# Altium schematic sheet MainSheet.SchDoc(MainSheet)
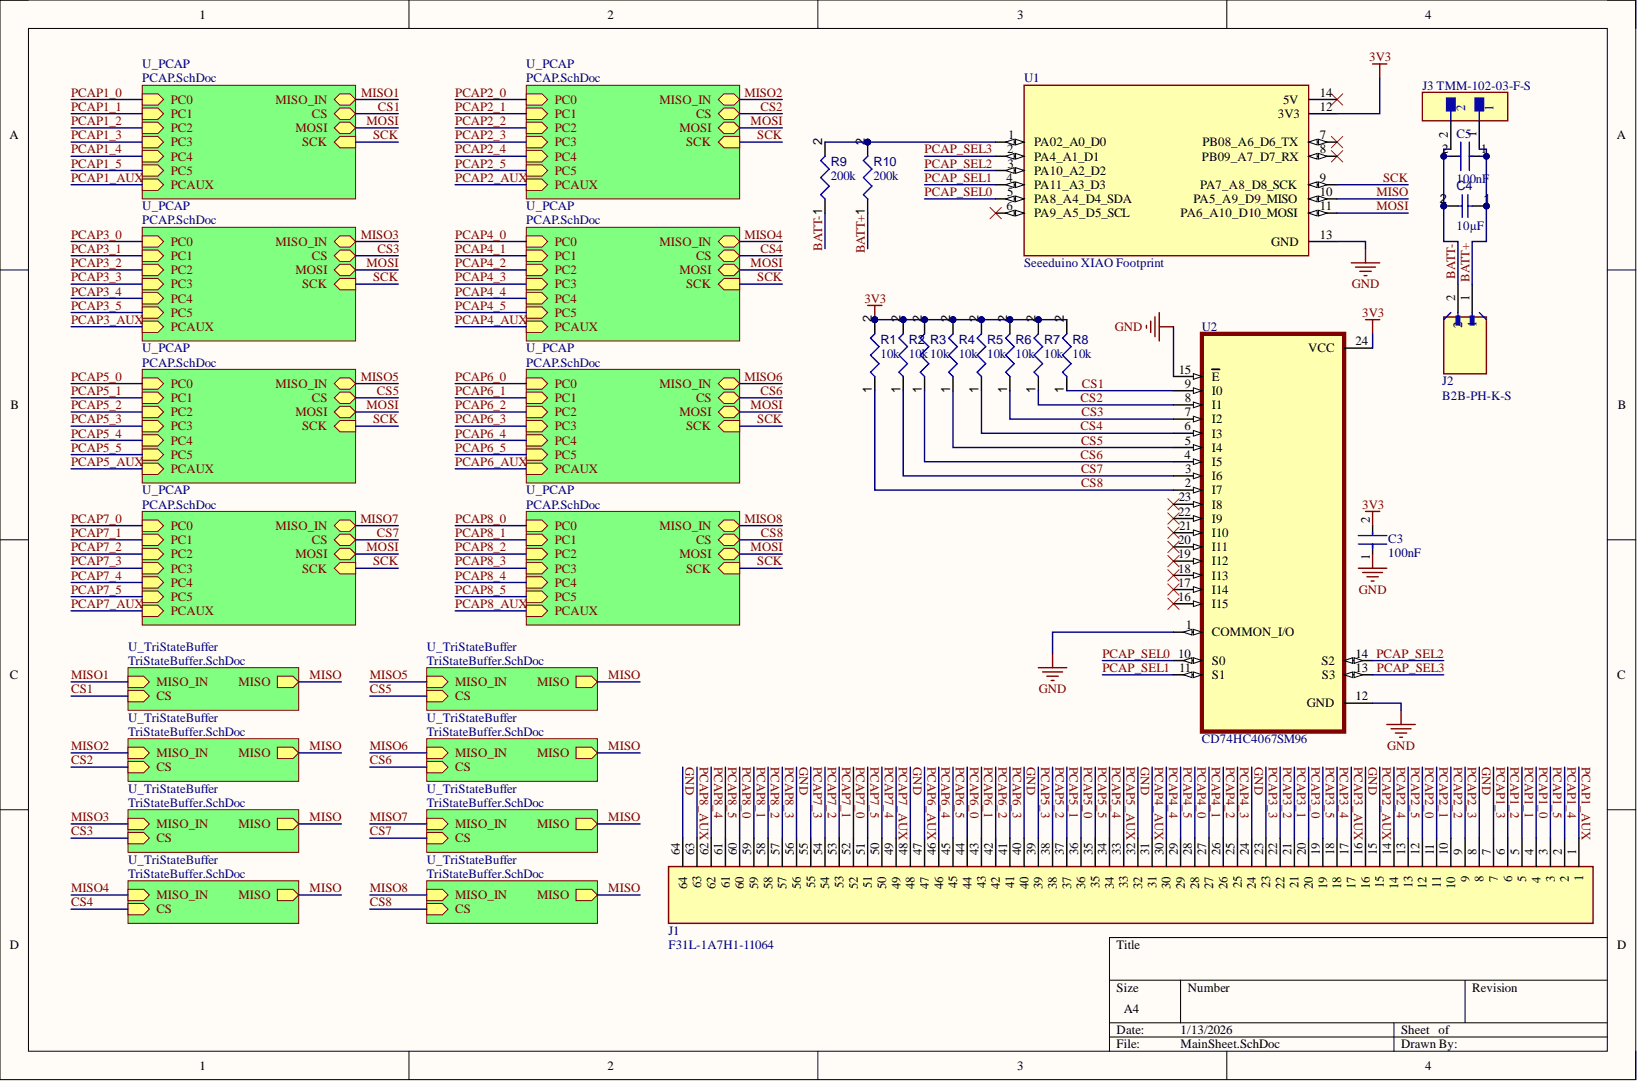
[diagram p0: MainSheet.SchDoc]

## Parts
C3  100nF
C4  value 10µF  (hidden comment: Capacitor 10µF +/-20% 10V 0603)
C5  100nF
J1  F31L-1A7H1-11064
J2  B2B-PH-K-S
J3  TMM-102-03-F-S
R1  value 10k  (hidden comment: 10KR2F)
R2  value 10k  (hidden comment: 10KR2F)
R3  value 10k  (hidden comment: 10KR2F)
R4  value 10k  (hidden comment: 10KR2F)
R5  value 10k  (hidden comment: 10KR2F)
R6  value 10k  (hidden comment: 10KR2F)
R7  value 10k  (hidden comment: 10KR2F)
R8  value 10k  (hidden comment: 10KR2F)
R9  value 200k  (hidden comment: Resistor 200K +/-1 % 0402 63 mW)
R10  value 200k  (hidden comment: Resistor 200K +/-1 % 0402 63 mW)
U1  Seeeduino XIAO Footprint
U2  CD74HC4067SM96

## Nets
3V3: C3.2 R1.2 R2.2 R3.2 R4.2 R5.2 R6.2 R7.2 R8.2 U1.12(3V3) U2.24(VCC)
BATT+: C4.1 C5.1 J2.1 J3.1 R10.1
BATT-: C4.2 C5.2 J2.2 J3.2 R9.1
CS1: R8.1 U2.9(I0)
CS2: R7.1 U2.8(I1)
CS3: R6.1 U2.7(I2)
CS4: R5.1 U2.6(I3)
CS5: R4.1 U2.5(I4)
CS6: R3.1 U2.4(I5)
CS7: R2.1 U2.3(I6)
CS8: R1.1 U2.2(I7)
GND: C3.1 J1.8 J1.16 J1.24 J1.32 J1.40 J1.48 J1.56 J1.64 U1.13(GND) U2.1(COMMON_I/O) U2.12(GND) U2.15(E)
MISO: U1.10(PA5_A9_D9_MISO)
MOSI: U1.11(PA6_A10_D10_MOSI)
PCAP1_0: J1.4
PCAP1_1: J1.5
PCAP1_2: J1.6
PCAP1_3: J1.7
PCAP1_4: J1.2
PCAP1_5: J1.3
PCAP1_AUX: J1.1
PCAP2_0: J1.12
PCAP2_1: J1.11
PCAP2_2: J1.10
PCAP2_3: J1.9
PCAP2_4: J1.14
PCAP2_5: J1.13
PCAP2_AUX: J1.15
PCAP3_0: J1.20
PCAP3_1: J1.21
PCAP3_2: J1.22
PCAP3_3: J1.23
PCAP3_4: J1.18
PCAP3_5: J1.19
PCAP3_AUX: J1.17
PCAP4_0: J1.28
PCAP4_1: J1.27
PCAP4_2: J1.26
PCAP4_3: J1.25
PCAP4_4: J1.30
PCAP4_5: J1.29
PCAP4_AUX: J1.31
PCAP5_0: J1.36
PCAP5_1: J1.37
PCAP5_2: J1.38
PCAP5_3: J1.39
PCAP5_4: J1.34
PCAP5_5: J1.35
PCAP5_AUX: J1.33
PCAP6_0: J1.44
PCAP6_1: J1.43
PCAP6_2: J1.42
PCAP6_3: J1.41
PCAP6_4: J1.46
PCAP6_5: J1.45
PCAP6_AUX: J1.47
PCAP7_0: J1.52
PCAP7_1: J1.53
PCAP7_2: J1.54
PCAP7_3: J1.55
PCAP7_4: J1.50
PCAP7_5: J1.51
PCAP7_AUX: J1.49
PCAP8_0: J1.60
PCAP8_1: J1.59
PCAP8_2: J1.58
PCAP8_3: J1.57
PCAP8_4: J1.62
PCAP8_5: J1.61
PCAP8_AUX: J1.63
PCAP_SEL0: U1.5(PA8_A4_D4_SDA) U2.10(S0)
PCAP_SEL1: U1.4(PA11_A3_D3) U2.11(S1)
PCAP_SEL2: U1.3(PA10_A2_D2) U2.14(S2)
PCAP_SEL3: U1.2(PA4_A1_D1) U2.13(S3)
SCK: U1.9(PA7_A8_D8_SCK)
NetR9_2: R9.2 R10.2 U1.1(PA02_A0_D0)
NetU1_6: U1.6(PA9_A5_D5_SCL)
NetU1_7: U1.7(PB08_A6_D6_TX)
NetU1_8: U1.8(PB09_A7_D7_RX)
NetU1_14: U1.14(5V)
NetU2_16: U2.16(I15)
NetU2_17: U2.17(I14)
NetU2_18: U2.18(I13)
NetU2_19: U2.19(I12)
NetU2_20: U2.20(I11)
NetU2_21: U2.21(I10)
NetU2_22: U2.22(I9)
NetU2_23: U2.23(I8)
MISO1:
MISO2:
MISO3:
MISO4:
MISO5:
MISO6:
MISO7:
MISO8:

## Library data of the parts
C3 = CMP-13271-001051-1: Cap Ceramic 1uF 25V X5R ±10% SMD 0402 +85°C Paper T/R; Capacitance: 1uF; Case Code (Imperial): 0402; Case Code (Metric): 1005; Case/Package: 0402; Component Kind: Standard; Depth: 0.5mm; Dielectric: X5R; Height: 0.5mm; Lead Free: Lead Free; Length: 1mm; Library Name: Altium Content Vault; Material: Ceramic; Max Operating Temperature: 85°C; Min Operating Temperature: -55°C; Mount: Surface Mount; Package Quantity: 10000; Packaging: Tape and Reel; Radiation Hardening: No; Thickness: 0.022inch; Tolerance: 10%; Voltage Rating: 25V; Width: 0.02inch
C4 = CMP-001-00075-4: Chip Capacitor, 10µF +/-20%, 10V, 0603, Thickness 1 mm; Tolerance: 10%; Case/Package: 0603; Component Kind: Standard; Max Operating Temperature: 85°C; Min Operating Temperature: -55°C; Mounting Technology: SMT; Pins: 2; Voltage Rating: 10V; Library Name: Joel Lee
C5 = CMP-13271-001051-1: Cap Ceramic 100nF 25V X5R ±10% SMD 0402 +85°C Paper T/R; Capacitance: 1uF; Case Code (Imperial): 0402; Case Code (Metric): 1005; Case/Package: 0402; Component Kind: Standard; Depth: 0.5mm; Dielectric: X5R; Height: 0.5mm; Lead Free: Lead Free; Length: 1mm; Library Name: Altium Content Vault; Material: Ceramic; Max Operating Temperature: 85°C; Min Operating Temperature: -55°C; Mount: Surface Mount; Package Quantity: 10000; Packaging: Tape and Reel; Radiation Hardening: No; Thickness: 0.022inch; Tolerance: 10%; Voltage Rating: 25V; Width: 0.02inch
J1 = CMP-002-00072-1: Flex Connector, 0.50mm Pitch, Height 2.55mm, Right angle, Flip type, ZIF, 64 position , With MYLAR; MF: Amphenol ICC (FCI); Case/Package: None; Check_prices: https://www.snapeda.com/parts/F31L-1A7H1-11064/Amphenol+FCI/view-part/?ref=eda; Component Kind: Standard; Component Type: Standard; Design Item ID: F31L-1A7H1-11064; MP: F31L-1A7H1-11064; Pins: 64; SnapEDA_Link: https://www.snapeda.com/parts/F31L-1A7H1-11064/Amphenol+FCI/view-part/?ref=snap; Source: F31L-1A7H1-11064.SchLib; Library Name: Joel Lee
J2 = CMP-002-00074-1: Connector Header Through Hole 2 position 0.079 (2.00mm); MF: JST Sales America Inc.; Case/Package: None; Check_prices: https://www.snapeda.com/parts/B2B-PH-K-S/JST/view-part/?ref=eda; Component Kind: Standard; Component Type: Standard; Design Item ID: B2B-PH-K-S; MP: B2B-PH-K-S; Pins: 2; SnapEDA_Link: https://www.snapeda.com/parts/B2B-PH-K-S/JST/view-part/?ref=snap; Source: B2B-PH-K-S.SchLib; Library Name: Joel Lee
J3 = CMP-02766-001614-1: CONN HEADER VERT 2POS 2MM; Gender: Male; Number of Contacts: 2; Component Kind: Standard; Contact Plating: Gold; Lead Free: Lead Free; Library Name: Altium Content Vault; Mount: Through Hole; Number of Rows: 1; Packaging: Bulk; Pitch: 2mm; Radiation Hardening: No; RoHS Compliant: Yes; Termination: Solder
R1-R8 = CMP-009-00066-2: 10K 0.063W 1% 0402 (1005 Metric)  SMD; Power: 63mW; Case/Package: 0402; Component Kind: Standard; Mounting Technology: SMT; Pins: 2; Tolerance: 1%; Library Name: Joel Lee
R9 R10 = CMP-009-00163-3: Chip Resistor, 200 kOhms, +/-1 %, 63 mW, -55 to 155 degC, 0402; Power: 63mW; Tolerance: 1%; Case/Package: 0402; Component Kind: Standard; Max Operating Temperature: 155°C; Min Operating Temperature: -55°C; Pins: 2; Library Name: Joel Lee
U1 = CMP-015-000000-4: Seeeduino XIAO Development Board, Pre-Soldered [Seeed Studio] 102010388 Seeeduino XIAO Development Board, Pre-Soldered; MF: Seeed Technology Co., Ltd; Availability: In Stock; Check_prices: https://www.snapeda.com/parts/102010388/Seeed+Technology+Co.%252C+Ltd/view-part/?ref=eda; Component Kind: Standard; Component Type: Standard; Design Item ID: 102010388; MP: 102010388; Package: None; Pin Count: 14; Price: None; Purchase-URL: https://pricing.snapeda.com/search/part/102010388/?ref=eda; SnapEDA_Link: https://www.snapeda.com/parts/102010388/Seeed+Technology+Co.%252C+Ltd/view-part/?ref=snap; Source: 102010388.SchLib; Library Name: Joel Lee
U2 = CMP-032-000000-2: 5-V, 16:1, 1-channel analog multiplexer; MF: Texas Instruments; Check_prices: https://www.snapeda.com/parts/CD74HC4067SM96/Texas+Instruments/view-part/?ref=eda; Component Kind: Standard; Component Type: Standard; Design Item ID: CD74HC4067SM96; MP: CD74HC4067SM96; Package: SSOP-24 Texas Instruments; Pin Count: 24; SnapEDA_Link: https://www.snapeda.com/parts/CD74HC4067SM96/Texas+Instruments/view-part/?ref=snap; Source: CD74HC4067SM96.SchLib; Library Name: Joel Lee
